# Altium schematic sheet TPS5430_buck_converter.SchDoc(TPS5430_buck_converter)
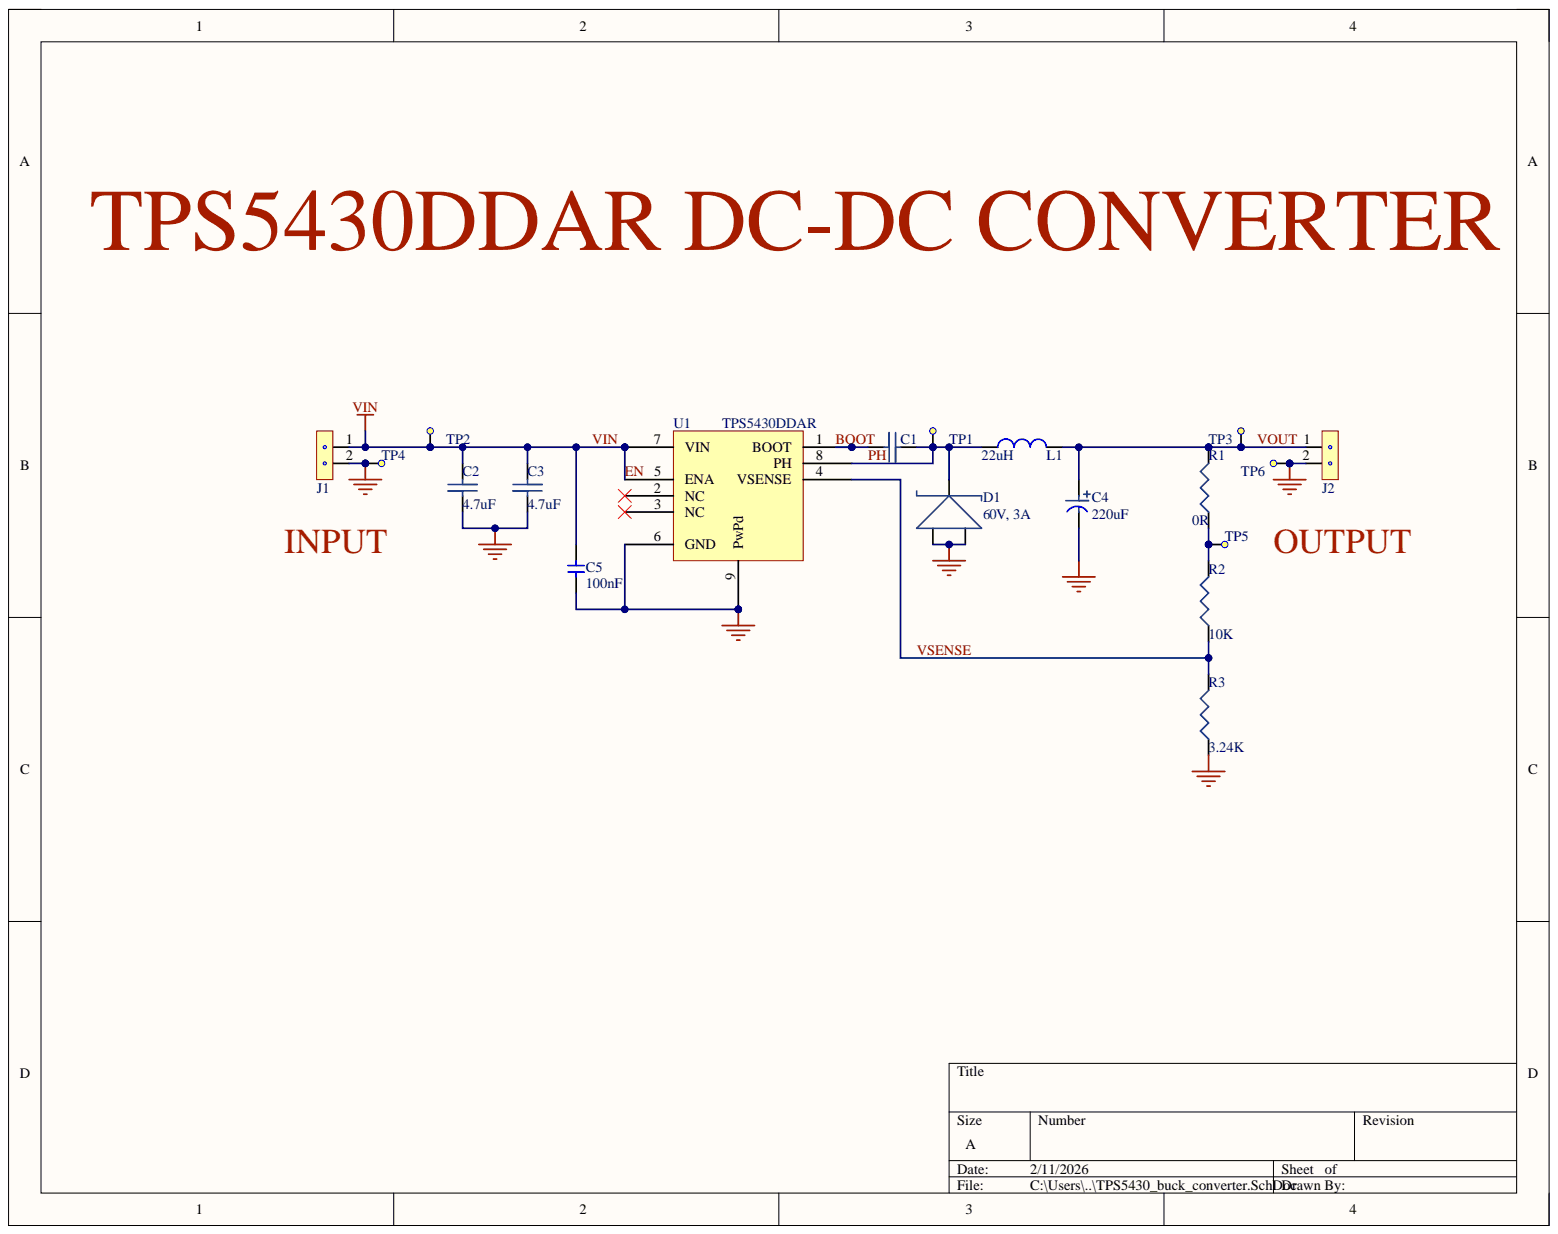
[diagram p0: TPS5430_buck_converter.SchDoc]

## Parts
C1  10nF, 50V  [C0603]  (value not drawn)
C2  4.7uF  [C1812]
C3  4.7uF  [C1812]
C4  220uF  [C10TPB]
C5  100nF  [C0805]
D1  60V, 3A  [TO229P990X238-3N]
J1  3.5mm 2 pin  [PHOENIX_1985807]  (value not drawn)
J2  (no value)  [PHOENIX_1985807 - duplicate]
L1  22uH  [SRR1208]
R1  0R  [R0805]
R2  10K  [R0603]
R3  3.24K  [R0603]
TP1  testpoint_smd  [TP_smd_1.5]  (value not drawn)
TP2  testpoint_smd  [TP_smd_1.5]  (value not drawn)
TP3  testpoint_smd  [TP_smd_1.5]  (value not drawn)
TP4  testpoint_smd  [TP_smd_1.5]  (value not drawn)
TP5  testpoint_smd  [TP_smd_1.5]  (value not drawn)
TP6  testpoint_smd  [TP_smd_1.5]  (value not drawn)
U1  TPS5430DDAR  [SO-PowerPAD-8_DDA]

## Nets
GND: C2.2 C3.2 C4.2 C5.1 D1.1 D1.3 J1.2 J2.2 R3.1 TP4.1 TP6.1 U1.6(GND) U1.9(PwPd)
BOOT: C1.1 U1.1(BOOT)
EN: C2.1 C3.1 C5.2 J1.1 TP2.1 U1.5(ENA) U1.7(VIN)
PH: C1.2 D1.4 L1.1 TP1.1 U1.8(PH)
VOUT: C4.1 J2.1 L1.2 R1.2 TP3.1
VSENSE: R2.1 R3.2 U1.4(VSENSE)
NetR1_1: R1.1 R2.2 TP5.1

Not connected: U1 2(NC) 3(NC)
